# Altium schematic sheet Ultrasonic_Driver.SchDoc
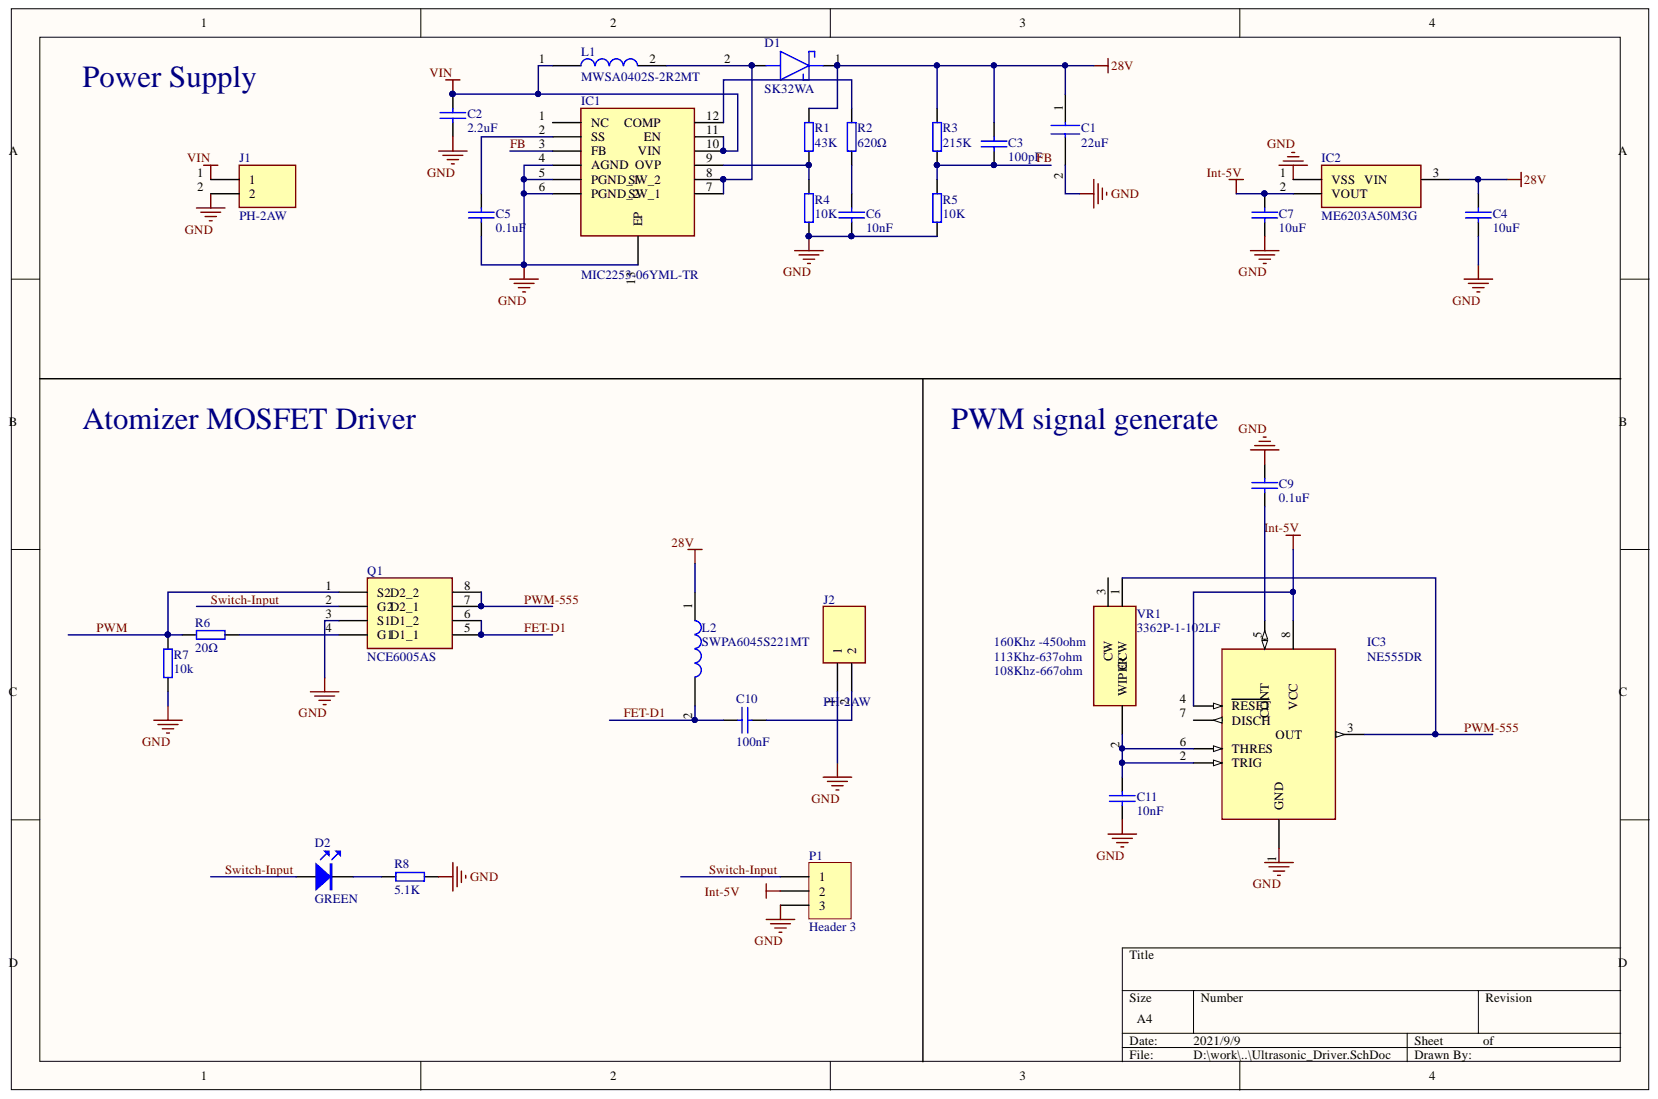
[diagram p0: Ultrasonic_Driver.SchDoc]

## Parts
C1  22uF  [CAPC2012X145N]
C2  2.2uF  [C0603]
C3  100pF  [C0603]
C4  10uF  [C0805]
C5  0.1uF  [C0603]
C6  10nF  [C0603]
C7  10uF  [C0603]
C9  0.1uF  [C0603]
C10  100nF  [C0805]
C11  10nF  [C0603]
D1  SK32WA  [SK32WA]
D2  GREEN  [LED_0603]
IC1  MIC2253-06YML-TR  [SON50P300X300X90-13N-D]
IC2  ME6203A50M3G  [ME6203A50M3G]
IC3  NE555DR  [SOIC127P600X175-8N]
J1  PH-2AW  [SHDR2W50P0X200_1X2_600X600X500P]
J2  PH-2AW  [SHDR2W50P0X200_1X2_600X600X500P]
L1  MWSA0402S-2R2MT  [INDPM4442X200N]
L2  SWPA6045S221MT  [SWPA6045S220MT]
P1  Header 3  [HDR1X3]
Q1  NCE6005AS  [SOIC127P600X175-8N]
R1  43K  [R0603]
R2  620Ω  [R0603]
R3  215K  [R0603]
R4  10K  [R0603]
R5  10K  [R0603]
R6  20Ω  [R0603]
R7  10k  [R0603]
R8  5.1K  [R0603]
VR1  3362P-1-102LF  [3362P1502LF]

## Nets
28V: C1.1 C3.2 C4.2 D1.1 IC2.3 L2.1 R1.1 R3.1
GND: C1.2 C2.1 C4.1 C5.1 C6.1 C7.1 C9.2 C11.1 IC1.4(AGND) IC1.5(PGND_1) IC1.6(PGND_2) IC1.13(EP) IC2.1 IC3.1(GND) J1.2 J2.1 P1.3 Q1.3(S1_D1_2) R4.2 R5.2 R7.2 R8.1
INT-5V: C7.2 IC2.2 IC3.4(RESET) IC3.8 P1.2
VIN: C2.2 IC1.10 IC1.11 J1.1 L1.1
FB: C3.1 IC1.3(FB) R3.2 R5.1
FET-D1: C10.2 L2.2 Q1.5 Q1.6
PWM: Q1.1(S2_D2_2) R6.1 R7.1
PWM-555: IC3.3 Q1.7 Q1.8 VR1.1
SWITCH-INPUT: D2.1 P1.1 Q1.2(G2)
NetC5_2: C5.2 IC1.2(SS)
NetC6_2: C6.2 R2.2
NetC9_1: C9.1 IC3.5
NetC10_1: C10.1 J2.2
NetC11_2: C11.2 IC3.2(TRIG) IC3.6(THRES) VR1.2
NetD1_2: D1.2 IC1.7 IC1.8 L1.2
NetD2_2: D2.2 R8.2
NetIC1_9: IC1.9 R1.2 R4.1
NetIC1_12: IC1.12 R2.1
NetQ1_4: Q1.4(G1) R6.2

Not connected: IC1 1(NC); IC3 7(DISCH); VR1 3

## Library data of the parts
C1 = C2012X5R1V226MT000E: Manufacturer_Name: TDK; Component Kind: Standard; Datasheet Link: https://componentsearchengine.com/Datasheets/1/C2012X5R1V226MT000E.pdf; Description: C2012X5R1V226MT000E; Height: 1.45mm; Manufacturer_Part_Number: C2012X5R1V226MT000E; Mouser Part Number: N/A; Mouser Price/Stock: https://www.mouser.co.uk/ProductDetail/TDK/C2012X5R1V226MT000E?qs=chTDxNqvsylp%252BladBrNxJA%3D%3D
C2 = CAP: 16V X5R; Component Kind: Standard; Library Name: Component.SchLib
C3 = CAP: 50V C0G; Component Kind: Standard; Library Name: Component.SchLib
C4 = CAP: 10uF ±10% 50V; Component Kind: Standard; Library Name: Component.SchLib
C5 = CAP: 16V X7R; Component Kind: Standard; Library Name: Component.SchLib
C6 = CAP: 50V X5R; Component Kind: Standard; Library Name: Component.SchLib
C7 C9 C11 = CAP: 16V Ceramic; Component Kind: Standard; Library Name: Component.SchLib
C10 = CAP: 100V X7R 10% 0805; Component Kind: Standard; Library Name: Component.SchLib
D1 = SK32WA: Schottky Diode; Manufacturer_Name: SHIKUES(时科); Component Kind: Standard; datasheet_link: https://atta.szlcsc.com/upload/public/pdf/source/20180323/DA73144C84EC669030D5D10416970BB4.pdf; Library Name: SamacSys.SchLib
D2 = LED: Component Kind: Standard; Description: LED; Library Name: Component.SchLib
IC1 = MIC2253-06YML-TR: Integrated Circuit; Manufacturer_Name: Microchip; Arrow Part Number: MIC2253-06YML-TR; Arrow Price/Stock: https://www.arrow.com/en/products/mic2253-06yml-tr/microchip-technology; Component Kind: Standard; Datasheet Link: http://ww1.microchip.com/downloads/en/DeviceDoc/mic2253.pdf; Height: 0.9mm; Manufacturer_Part_Number: MIC2253-06YML-TR; Mouser Part Number: 998-MIC2253-06YMLTR; Mouser Price/Stock: https://www.mouser.co.uk/ProductDetail/Microchip-Technology-Micrel/MIC2253-06YML-TR?qs=Y3Q3JoKAO1QuWm%252Bu%2Ft%252BgHw%3D%3D; Library Name: samacsys.schlib
IC2 = ME6203A50M3G: LDO 5V, 100mA, up to 40V Input; Component Kind: Standard; Library Name: SamacSys.SchLib
IC3 = NE555DR: Integrated Circuit; Manufacturer_Name: Texas Instruments; Component Kind: Standard; Datasheet Link: http://www.ti.com/lit/ds/symlink/ne555.pdf; Height: 1.75mm; Library Name: SamacSys.SchLib; Manufacturer_Part_Number: NE555DR; Mouser Part Number: 595-NE555DR; Mouser Price/Stock: https://www.mouser.com/Search/Refine.aspx?Keyword=595-NE555DR; RS Part Number: 1218414; RS Price/Stock: http://uk.rs-online.com/web/p/products/1218414
J1 J2 = PH-2AW: Connector; Manufacturer_Name: Boom Precision Electric; Component Kind: Standard; Datasheet Link: https://lcsc.com/product-detail/Wire-To-Board-Wire-To-Wire-Connector_Boom-Precision-Elec-PH-2AW-2P-pitch2-0mm-Lying-in_C16965.html; Height: 5mm; Manufacturer_Part_Number: PH-2AW
L1 = MWSA0402S-3R3MT: Inductor; Manufacturer_Name: SUNLORD; Component Kind: Standard; Datasheet Link: https://atta.szlcsc.com/upload/public/pdf/source/20190729/C408335_A7E6B668A7D3CE7F04476E0D34F3244A.pdf; Height: 2mm; Manufacturer_Part_Number: MWSA0402S-2R2MT; Library Name: samacsys.schlib
L2 = SWPA6045S220MT: Inductor; Manufacturer_Name: SUNLORD; Component Kind: Standard; Datasheet Link: https://datasheet.lcsc.com/szlcsc/Sunlord-SWPA6045S221MT_C91047.pdf; Height: 4.5mm; Manufacturer_Part_Number: SWPA6045S221MT
P1 = Header 3: Header, 3-Pin; Component Kind: Standard; LatestRevisionDate: 17-Jul-2002; LatestRevisionNote: Re-released for DXP Platform.; Library Name: Miscellaneous Connectors.IntLib; Signal Integrity: Connector; Publisher: Altium Limited
Q1 = NCE6005AS: MOSFET (N-Channel); Manufacturer_Name: Wuxi NCE Power Semiconductor; Component Kind: Standard; Datasheet Link: https://datasheet.lcsc.com/szlcsc/Wuxi-NCE-Power-Semiconductor-NCE6005AS_C126151.pdf; Height: 1.75mm; Manufacturer_Part_Number: NCE6005AS
R1-R5 = RES: X7R 0603 1% 1/16W; Component Kind: Standard; Library Name: Component.SchLib
R6 R7 = RES: 16V; Component Kind: Standard; Library Name: Component.SchLib
R8 = RES: 电阻; Component Kind: Standard; Library Name: Component.SchLib
VR1 = 3362P-1-502LF: Variable Resistor; Manufacturer_Name: Bourns; Arrow Part Number: 3362P-1-502LF; Arrow Price/Stock: https://www.arrow.com/en/products/3362p-1-502lf/bourns; Component Kind: Standard; Datasheet Link: https://www.bourns.com/docs/Product-Datasheets/3362.pdf; Height: 4.88mm; Manufacturer_Part_Number: 3362P-1-502LF; Mouser Part Number: 652-3362P-1-502LF; Mouser Price/Stock: https://www.mouser.co.uk/ProductDetail/Bourns/3362P-1-502LF?qs=tAkzGBbgkTPEMzlWmwHyDQ%3D%3D
